# Altium schematic sheet csi.SchDoc(csi)
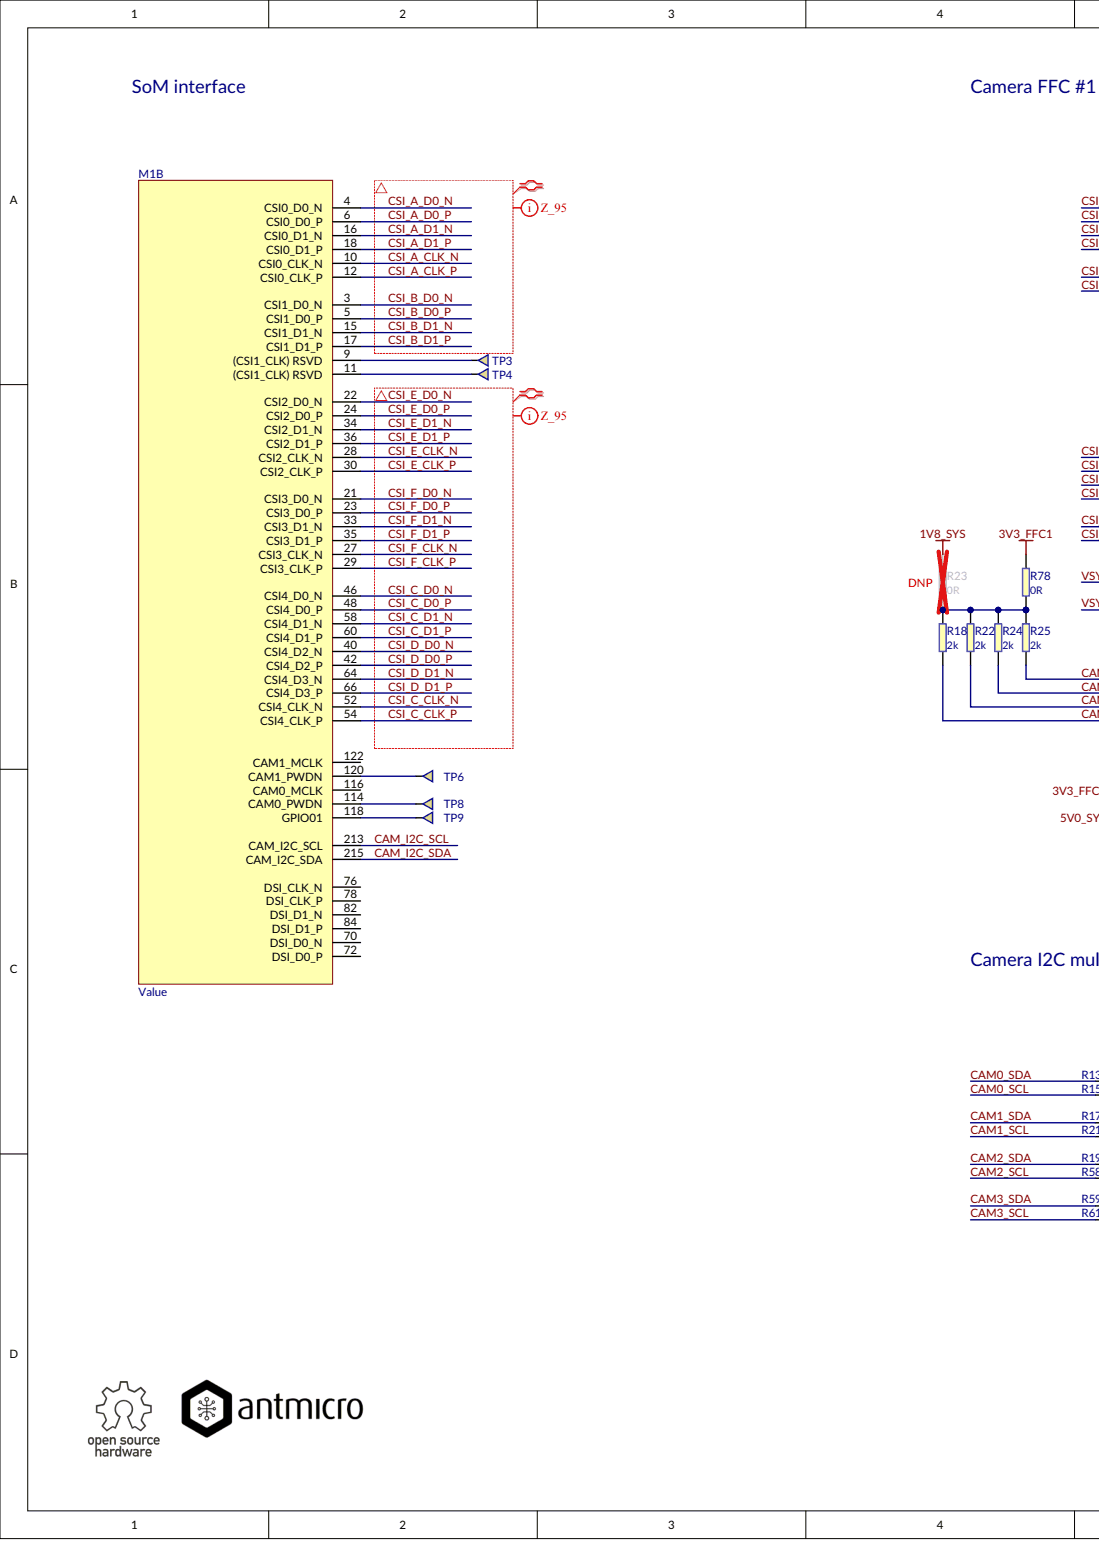
[diagram p0: csi.SchDoc, left]
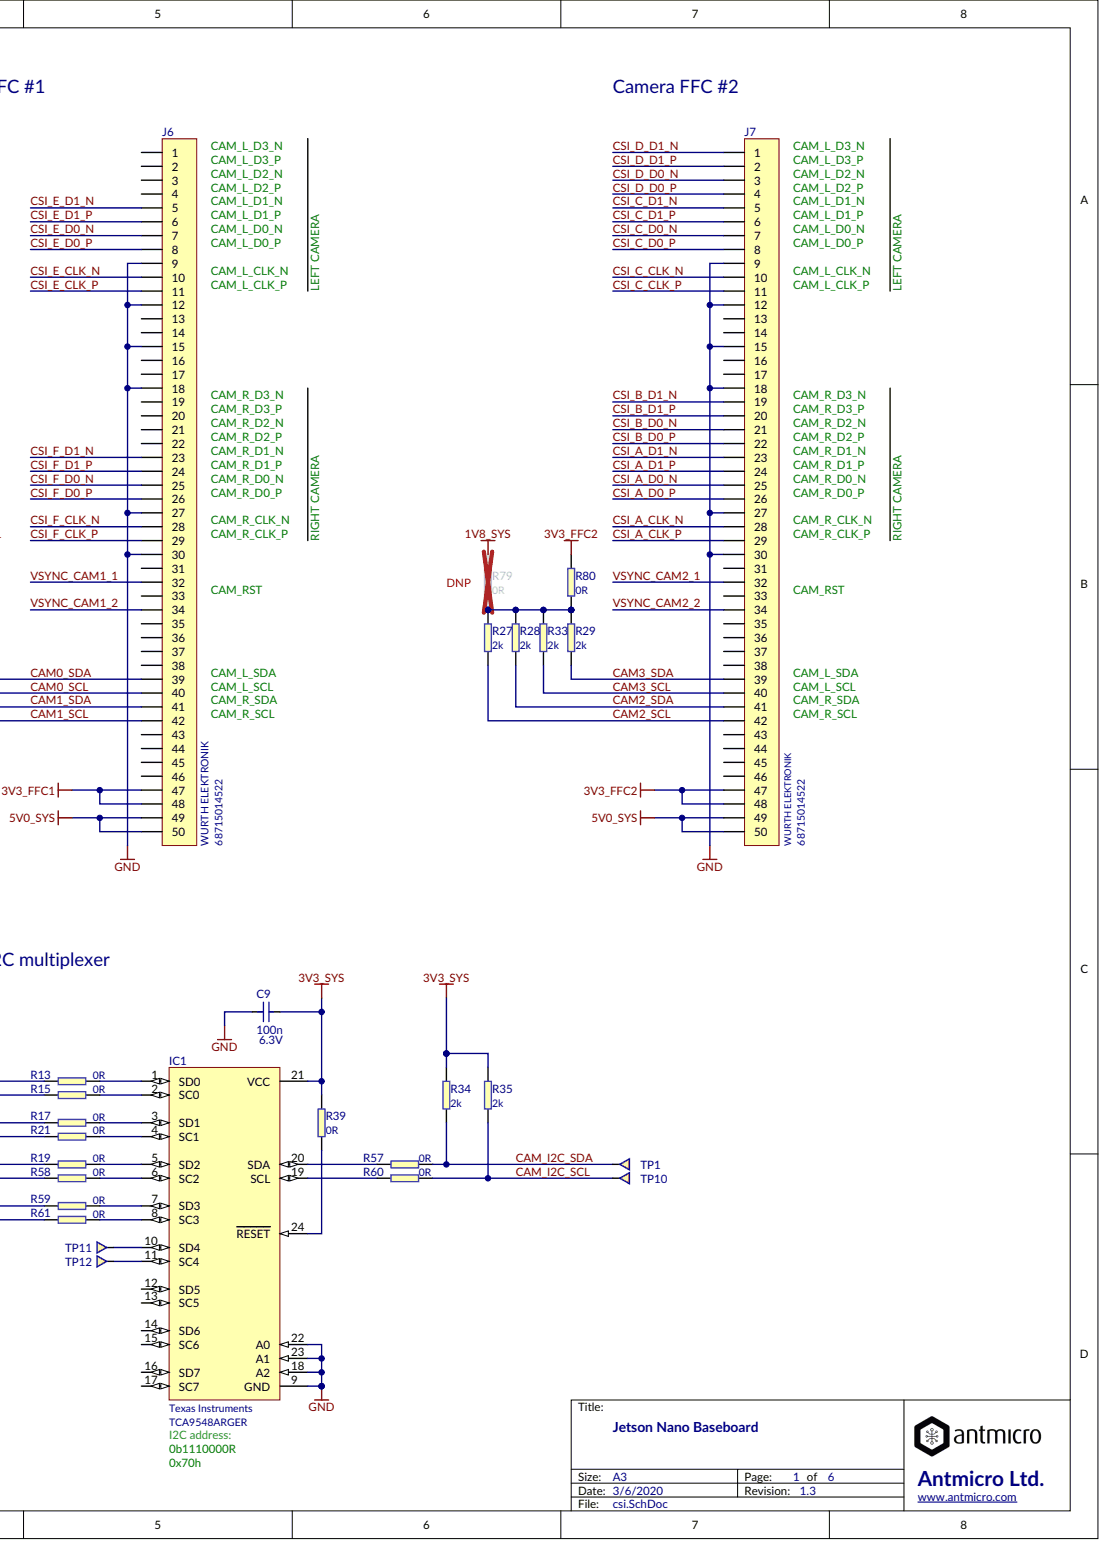
[diagram p1: csi.SchDoc, right]

## Parts
C9  Voltage: 6.3V  (hidden comment: 100n)
IC1  Manufacturer: Texas Instruments  (hidden comment: TCA9548ARGER)
J6  Manufacturer: WURTH ELEKTRONIK  (hidden comment: 68715014522)
J7  Manufacturer: WURTH ELEKTRONIK  (hidden comment: 68715014522)
M1  =Value  (part B)
R13  0R
R15  0R
R17  0R
R18  2k
R19  0R
R21  0R
R22  2k
R23  0R
R24  2k
R25  2k
R27  2k
R28  2k
R29  2k
R33  2k
R34  2k
R35  2k
R39  0R
R57  0R
R58  0R
R59  0R
R60  0R
R61  0R
R78  0R
R79  0R
R80  0R
TP1  Testpad  (value not drawn)
TP3  Testpad  (value not drawn)
TP4  Testpad  (value not drawn)
TP6  Testpad  (value not drawn)
TP8  Testpad  (value not drawn)
TP9  Testpad  (value not drawn)
TP10  Testpad  (value not drawn)
TP11  Testpad  (value not drawn)
TP12  Testpad  (value not drawn)

## Nets
1V8_SYS: R23.2 R79.2
3V3_FFC1: J6.47 J6.48 R78.2
3V3_FFC2: J7.47 J7.48 R80.2
3V3_SYS: C9.2 IC1.21(VCC) R34.1 R35.1 R39.2
5V0_SYS: J6.49 J6.50 J7.49 J7.50
GND: C9.1 IC1.9(GND) IC1.18(A2) IC1.22(A0) IC1.23(A1) J6.9 J6.12 J6.15 J6.18 J6.27 J6.30 J7.9 J7.12 J7.15 J7.18 J7.27 J7.30
CAM0_SCL: J6.40 R15.1 R24.2
CAM0_SDA: J6.39 R13.1 R25.2
CAM1_SCL: J6.42 R18.2 R21.1
CAM1_SDA: J6.41 R17.1 R22.2
CAM2_SCL: J7.42 R27.2 R58.1
CAM2_SDA: J7.41 R19.1 R28.2
CAM3_SCL: J7.40 R33.2 R61.1
CAM3_SDA: J7.39 R29.2 R59.1
CAM_I2C_SCL: M1.213(CAM_I2C_SCL) R35.2 R60.2 TP10.1
CAM_I2C_SDA: M1.215(CAM_I2C_SDA) R34.2 R57.2 TP1.1
CSI_A_CLK_N: J7.28 M1.10(CSI0_CLK_N)
CSI_A_CLK_P: J7.29 M1.12(CSI0_CLK_P)
CSI_A_D0_N: J7.25 M1.4(CSI0_D0_N)
CSI_A_D0_P: J7.26 M1.6(CSI0_D0_P)
CSI_A_D1_N: J7.23 M1.16(CSI0_D1_N)
CSI_A_D1_P: J7.24 M1.18(CSI0_D1_P)
CSI_B_D0_N: J7.21 M1.3(CSI1_D0_N)
CSI_B_D0_P: J7.22 M1.5(CSI1_D0_P)
CSI_B_D1_N: J7.19 M1.15(CSI1_D1_N)
CSI_B_D1_P: J7.20 M1.17(CSI1_D1_P)
CSI_C_CLK_N: J7.10 M1.52(CSI4_CLK_N)
CSI_C_CLK_P: J7.11 M1.54(CSI4_CLK_P)
CSI_C_D0_N: J7.7 M1.46(CSI4_D0_N)
CSI_C_D0_P: J7.8 M1.48(CSI4_D0_P)
CSI_C_D1_N: J7.5 M1.58(CSI4_D1_N)
CSI_C_D1_P: J7.6 M1.60(CSI4_D1_P)
CSI_D_D0_N: J7.3 M1.40(CSI4_D2_N)
CSI_D_D0_P: J7.4 M1.42(CSI4_D2_P)
CSI_D_D1_N: J7.1 M1.64(CSI4_D3_N)
CSI_D_D1_P: J7.2 M1.66(CSI4_D3_P)
CSI_E_CLK_N: J6.10 M1.28(CSI2_CLK_N)
CSI_E_CLK_P: J6.11 M1.30(CSI2_CLK_P)
CSI_E_D0_N: J6.7 M1.22(CSI2_D0_N)
CSI_E_D0_P: J6.8 M1.24(CSI2_D0_P)
CSI_E_D1_N: J6.5 M1.34(CSI2_D1_N)
CSI_E_D1_P: J6.6 M1.36(CSI2_D1_P)
CSI_F_CLK_N: J6.28 M1.27(CSI3_CLK_N)
CSI_F_CLK_P: J6.29 M1.29(CSI3_CLK_P)
CSI_F_D0_N: J6.25 M1.21(CSI3_D0_N)
CSI_F_D0_P: J6.26 M1.23(CSI3_D0_P)
CSI_F_D1_N: J6.23 M1.33(CSI3_D1_N)
CSI_F_D1_P: J6.24 M1.35(CSI3_D1_P)
VSYNC_CAM1_1: J6.32
VSYNC_CAM1_2: J6.34
VSYNC_CAM2_1: J7.32
VSYNC_CAM2_2: J7.34
NetIC1_1: IC1.1(SD0) R13.2
NetIC1_2: IC1.2(SC0) R15.2
NetIC1_3: IC1.3(SD1) R17.2
NetIC1_4: IC1.4(SC1) R21.2
NetIC1_5: IC1.5(SD2) R19.2
NetIC1_6: IC1.6(SC2) R58.2
NetIC1_7: IC1.7(SD3) R59.2
NetIC1_8: IC1.8(SC3) R61.2
NetIC1_10: IC1.10(SD4) TP11.1
NetIC1_11: IC1.11(SC4) TP12.1
NetIC1_19: IC1.19(SCL) R60.1
NetIC1_20: IC1.20(SDA) R57.1
NetIC1_24: IC1.24(RESET) R39.1
NetM1_9: M1.9((CSI1_CLK)_RSVD) TP3.1
NetM1_11: M1.11((CSI1_CLK)_RSVD) TP4.1
NetM1_114: M1.114(CAM0_PWDN) TP8.1
NetM1_118: M1.118(GPIO01) TP9.1
NetM1_120: M1.120(CAM1_PWDN) TP6.1
NetR18_1: R18.1 R22.1 R23.1 R24.1 R25.1 R78.1
NetR27_1: R27.1 R28.1 R29.1 R33.1 R79.1 R80.1
N00119:
N00120:
N00121:
N00122:

Not connected: IC1 12(SD5) 13(SC5) 14(SD6) 15(SC6) 16(SD7) 17(SC7); J6 1 2 3 4 13 14 16 17 19 20 21 22 31 33 35 36 37 38 43 44 45 46; J7 13 14 16 17 31 33 35 36 37 38 43 44 45 46; M1 70(DSI_D0_N) 72(DSI_D0_P) 76(DSI_CLK_N) 78(DSI_CLK_P) 82(DSI_D1_N) 84(DSI_D1_P) 116(CAM0_MCLK) 122(CAM1_MCLK)

## Library data of the parts
C9 = (no symbol name): Manufacturer: TDK; PartNumber: C_100n_0402; PartSymbol: C1005X5R0J104K050BA; Value: 100n
J6 J7 = (no symbol name): PartNumber: WE-687-150-145-22; Pinsnumber: 50; Value: 68715014522; PartSymbol: 68715014522
M1 = (no symbol name): PartNumber: jetson-nano-new-release
R13 R15 R17 R19 R21 R23 R39 R57-R61 R78-R80 = (no symbol name): Manufacturer: Panasonic; PartNumber: R_0R_0402; PartSymbol: ERJ2GE0R00X; Value: 0R
R18 R22 R24 R25 R27-R29 R33-R35 = (no symbol name): PartNumber: R_2k_0402; Manufacturer: VISHAY; PartSymbol: CRCW04022K00FKED; Value: 2k
TP1 TP3 TP4 TP6 TP8-TP12 = (no symbol name): PartNumber: TP-R-1.5
IC1 = (no symbol name): Value: TCA9548ARGER; PartNumber: TCA9548ARGER; PartSymbol: TCA9548ARGER
